# Altium schematic sheet SensorSGP30.SchDoc
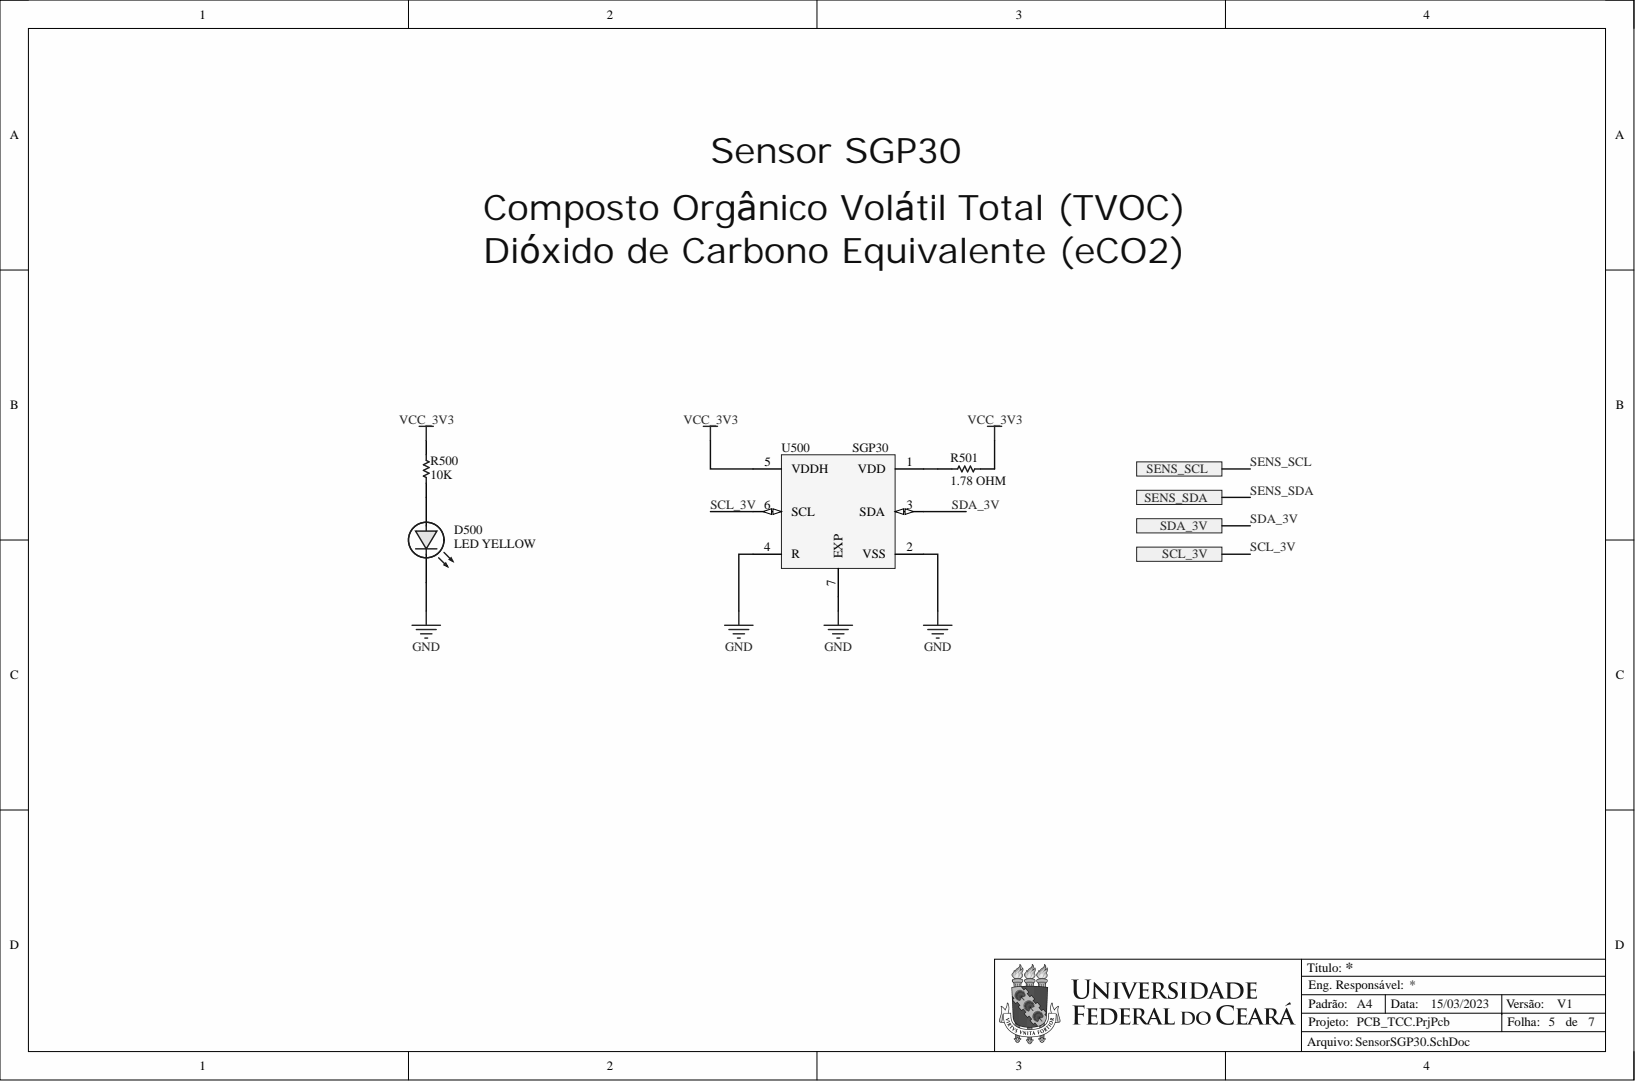
[diagram p0: SensorSGP30.SchDoc]

## Parts
D500  LED YELLOW  [LED_YELLOW_SMD_0603]
R500  10K  [RES_0603]
R501  1.78 OHM  [RES_0603]
U500  SGP30  [SGP30]

## Ports
SCL_3V, SDA_3V, SENS_SCL, SENS_SDA

## Nets
GND: D500.K U500.2(VSS) U500.4(R) U500.7(EXP)
VCC_3V3: R500.2 R501.2 U500.5(VDDH)
SCL_3V: U500.6(SCL) ; port SCL_3V
SDA_3V: U500.3(SDA) ; port SDA_3V
NetD500_A: D500.A R500.1
NetR501_1: R501.1 U500.1(VDD)
SENS_SCL: ; port SENS_SCL
SENS_SDA: ; port SENS_SDA

## Library data of the parts
D500 = LED_YELLOW_SMD_0603: Component Kind: Standard; Supplier 1: Digi-Key; Supplier Part Number 1: 754-1124-1-ND; Library Name: PCB_TCC.SchLib
R500 = RES_10K_0603: Component Kind: Standard; Supplier 1: Digi-Key Marketplace; Supplier Part Number 1: 2571-RM06J103CTTR-ND; Library Name: PCB_TCC.SchLib
R501 = RES_1.78OHM_0603: Component Kind: Standard; Supplier 1: Arrow Electronics; Supplier Part Number 1: RMCF0603FT1R78; Library Name: PCB_TCC.SchLib
U500 = SGP30: Component Kind: Standard; Supplier 1: Digi-Key; Supplier Part Number 1: 1649-1084-1-ND; Library Name: PCB_TCC.SchLib
